# Altium schematic sheet Sheet7.SchDoc(Sheet7)
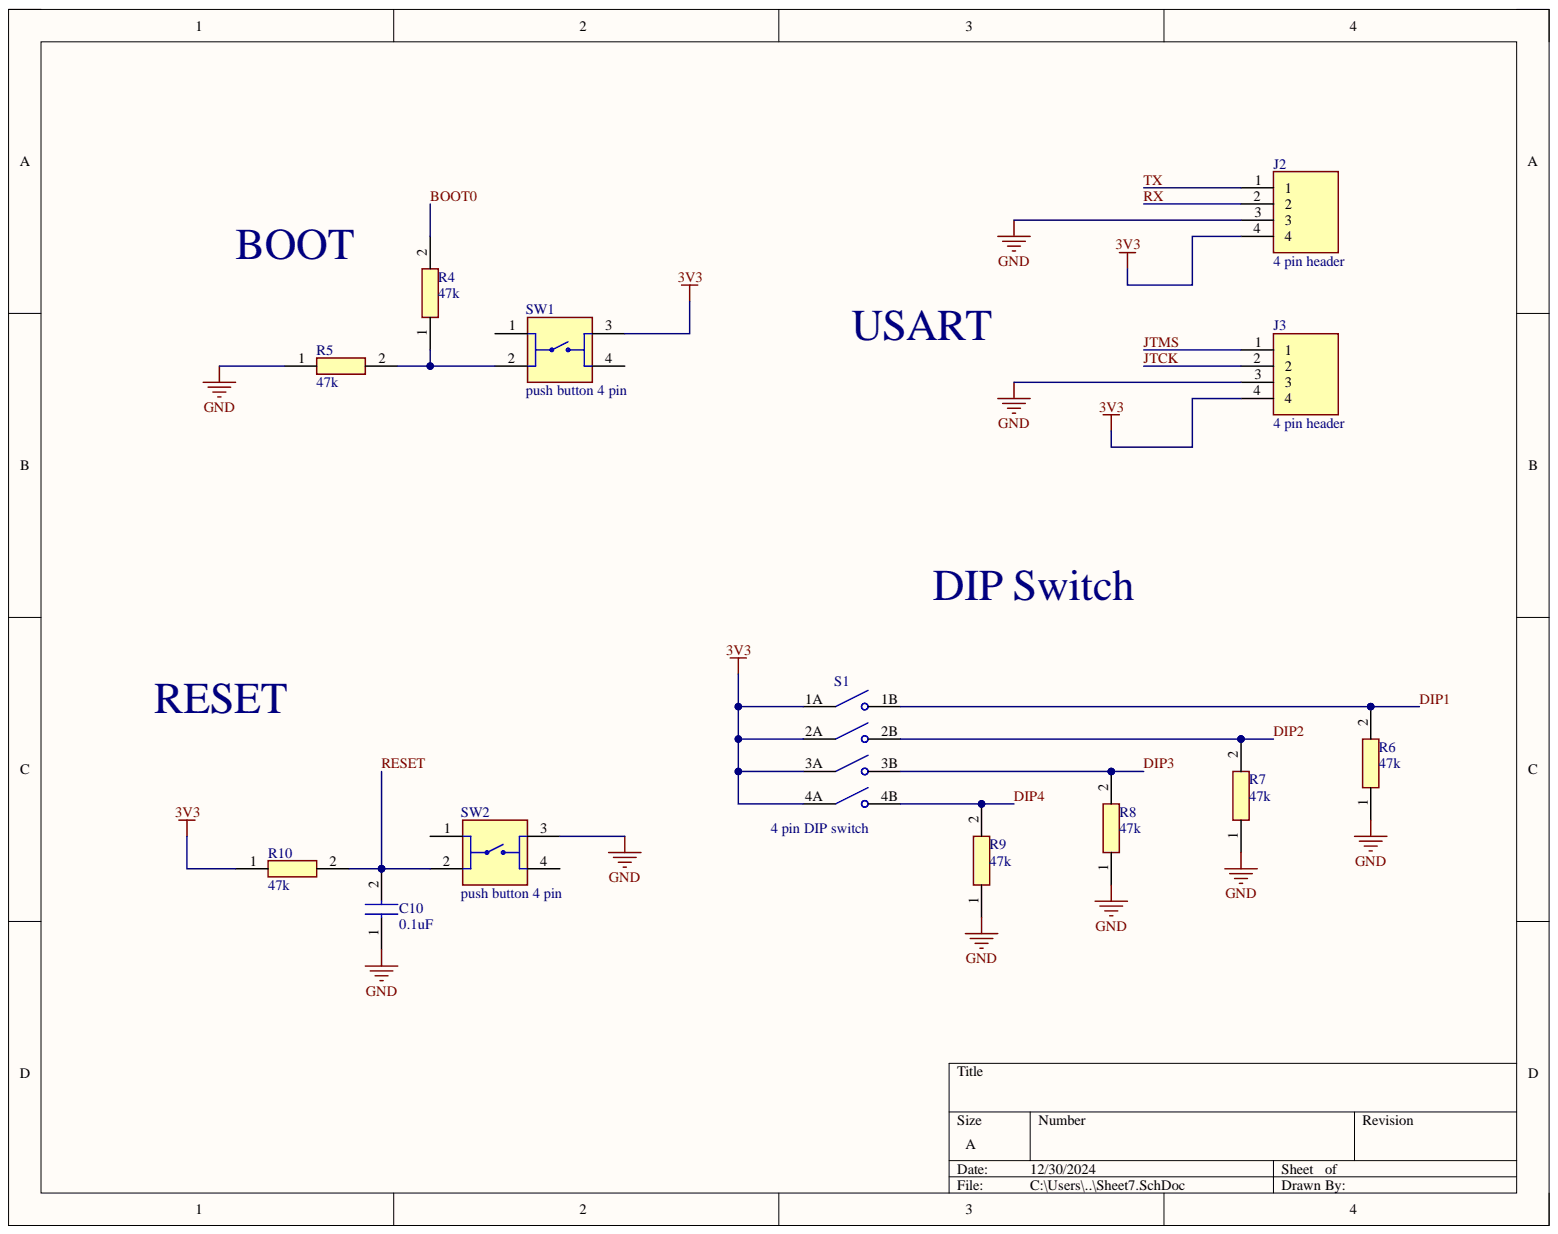
[diagram p0: Sheet7.SchDoc]

## Parts
C10  0.1uF  [CAPC1005X55N]
J2  4 pin header  [RHDR4W68P0X254_1X4_1016X356X889P]
J3  4 pin header  [RHDR4W68P0X254_1X4_1016X356X889P]
R4  47k  [ERA2AED122X]
R5  47k  [ERA2AED122X]
R6  47k  [ERA2AED122X]
R7  47k  [ERA2AED122X]
R8  47k  [ERA2AED122X]
R9  47k  [ERA2AED122X]
R10  47k  [ERA2AED122X]
S1  4 pin DIP switch  [SW_DS01C-254-S-04BE]
SW1  push button 4 pin  [push button 4 pin]
SW2  push button 4 pin  [push button 4 pin]

## Nets
3V3: J2.4 J3.4 R10.1 S1.1A S1.2A S1.3A S1.4A SW1.3
GND: C10.1 J2.3 J3.3 R5.1 R6.1 R7.1 R8.1 R9.1 SW2.3
BOOT0: R4.2
DIP1: R6.2 S1.1B
DIP2: R7.2 S1.2B
DIP3: R8.2 S1.3B
DIP4: R9.2 S1.4B
JTCK: J3.2
JTMS: J3.1
RESET: C10.2 R10.2 SW2.2
RX: J2.2
TX: J2.1
NetR4_1: R4.1 R5.2 SW1.2

Not connected: SW1 1 4; SW2 1 4

## Library data of the parts
C10 = 0.1uF: 0.1uf SMD 25V cermaic; Manufacturer_Name: TAIYO YUDEN; Manufacturer_Part_Number: MBAST105SB7104KFNA01; Component Kind: Standard; Datasheet Link: https://ds.yuden.co.jp/TYCOMPAS/or/specSheet?pn=MBAST105SB7104KFNA01; Height: 0.55mm; Library Name: Schlib1.SchLib; Mouser Part Number: 963-BAST105SB7104KF; Mouser Price/Stock: https://www.mouser.co.uk/ProductDetail/TAIYO-YUDEN/MBAST105SB7104KFNA01?qs=tlsG%2FOw5FFj0FpJuqULGiw%3D%3D
J2 J3 = 4 pin header: Connector; Manufacturer_Name: TE Connectivity; Manufacturer_Part_Number: 5-146763-6; Arrow Part Number: 5-146763-6; Arrow Price/Stock: https://www.arrow.com/en/products/5-146763-6/te-connectivity?region=nac; Component Kind: Standard; Datasheet Link: https://www.te.com/commerce/DocumentDelivery/DDEController?Action=showdoc&DocId=Customer+Drawing%7F146763%7FH4%7Fpdf%7FEnglish%7FENG_CD_146763_H4.pdf%7F5-146763-6; Height: 8.89mm; Library Name: Schlib1.SchLib; Mouser Part Number: 571-5-146763-6; Mouser Price/Stock: https://www.mouser.co.uk/ProductDetail/TE-Connectivity/5-146763-6?qs=0lP%252BFeTxe8ZqcqByeRhudw%3D%3D
R4-R10 = 47k: Thin Film Resistors 47K OHM .1% 15PPM 1/16W; Manufacturer_Name: Panasonic; Manufacturer_Part_Number: ERA-2APB473X; Component Kind: Standard; Datasheet Link: http://industrial.panasonic.com/cdbs/www-data/pdf/RDM0000/AOA0000C307.pdf; Height: 0.4mm; Library Name: Schlib1.SchLib; Mouser Part Number: 667-ERA-2APB473X; Mouser Price/Stock: https://www.mouser.co.uk/ProductDetail/Panasonic/ERA-2APB473X?qs=PgLP5Altfow2TfhzIUmQPg%3D%3D
S1 = 4 pin DIP switch: 4 Position, Through Hole, 2.54 mm Pitch, Raised Slide Actuator, Convex Bottom, Short Pin, DIP Switch; MF: CUI Devices; MP: DS01C-254-S-04BE; Availability: In Stock; Check_prices: https://www.snapeda.com/parts/DS01C-254-S-04BE/CUI+Devices/view-part/?ref=eda; Component Kind: Standard; CUI_purchase_URL: https://www.cuidevices.com/product/switches/dip-switches/ds01-254-series?utm_source=snapeda.com&utm_medium=referral&utm_campaign=snapedaBOM; Library Name: Schlib1.SchLib; Package: None; Price: None; Purchase-URL: https://pricing.snapeda.com/search/part/DS01C-254-S-04BE/?ref=eda; SnapEDA_Link: https://www.snapeda.com/parts/DS01C-254-S-04BE/CUI+Devices/view-part/?ref=snap
SW1 SW2 = push button 4 pin: Switch Push Button OFF (ON) SPST Round Button 0.05A 24VDC Momentary Contact PC Pins Thru-Hole; MF: TE Connectivity; MP: 1825910-6; Check_prices: https://www.snapeda.com/parts/1825910-6/TE+Connectivity+ALCOSWITCH+Switches/view-part/?ref=eda; Component Kind: Standard; Digi-Key_Part_Number: 450-1650-ND; Library Name: Schlib1.SchLib; Package: None; Purchase-URL: https://pricing.snapeda.com/search/part/1825910-6/?ref=eda; SnapEDA_Link: https://www.snapeda.com/parts/1825910-6/TE+Connectivity+ALCOSWITCH+Switches/view-part/?ref=snap
